# Altium schematic sheet Buck Converter.SchDoc(Designator)
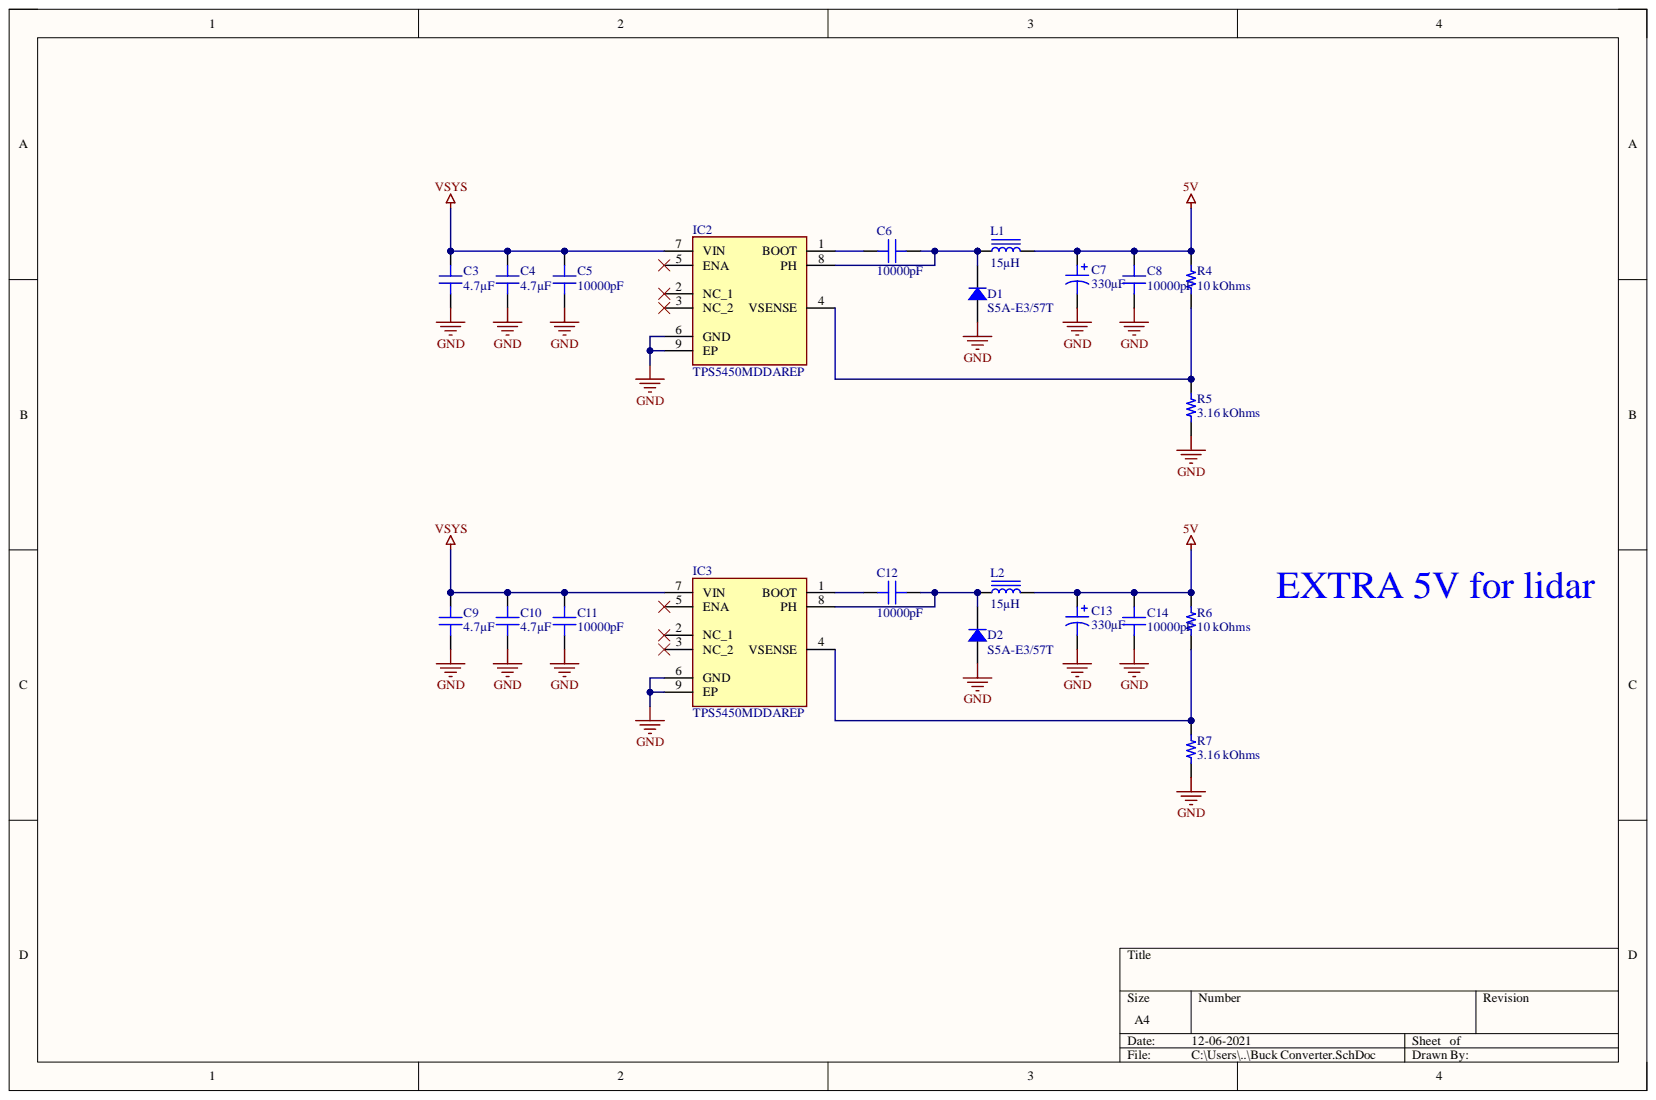
[diagram p0: Buck Converter.SchDoc]

## Parts
C3  4.7µF  [CAP 1206_3216 - 1.0MM]
C4  4.7µF  [CAP 1206_3216 - 1.0MM]
C5  10000pF  [CAP 0603_1608]
C6  10000pF  [CAP 0603_1608]
C7  330µF  [CAP ALSMD 6.6MM H7.7MM]
C8  10000pF  [CAP 0603_1608]
C9  4.7µF  [CAP 1206_3216 - 1.0MM]
C10  4.7µF  [CAP 1206_3216 - 1.0MM]
C11  10000pF  [CAP 0603_1608]
C12  10000pF  [CAP 0603_1608]
C13  330µF  [CAP ALSMD 6.6MM H7.7MM]
C14  10000pF  [CAP 0603_1608]
D1  S5A-E3/57T  [DO214AB SMC]
D2  S5A-E3/57T  [DO214AB SMC]
IC2  TPS5450MDDAREP  [SOIC127P600X170-9N]
IC3  TPS5450MDDAREP  [SOIC127P600X170-9N]
L1  15µH  [BOURNS SRR1280]
L2  15µH  [BOURNS SRR1280]
R4  10 kOhms  [RES 0603_1608]
R5  3.16 kOhms  [RES 0603_1608]
R6  10 kOhms  [RES 0603_1608]
R7  3.16 kOhms  [RES 0603_1608]

## Nets
5V: C7.1 C8.2 C13.1 C14.2 L1.2 L2.2 R4.2 R6.2
GND: C3.1 C4.1 C5.1 C7.2 C8.1 C9.1 C10.1 C11.1 C13.2 C14.1 D1.1 D2.1 IC2.6(GND) IC2.9(EP) IC3.6(GND) IC3.9(EP) R5.1 R7.1
VSYS: C3.2 C4.2 C5.2 C9.2 C10.2 C11.2 IC2.7(VIN) IC3.7(VIN)
NetC6_1: C6.1 D1.2 IC2.8(PH) L1.1
NetC6_2: C6.2 IC2.1(BOOT)
NetC12_1: C12.1 D2.2 IC3.8(PH) L2.1
NetC12_2: C12.2 IC3.1(BOOT)
NetIC2_4: IC2.4(VSENSE) R4.1 R5.2
NetIC3_4: IC3.4(VSENSE) R6.1 R7.2

Not connected: IC2 2(NC_1) 3(NC_2) 5(ENA); IC3 2(NC_1) 3(NC_2) 5(ENA)

## Library data of the parts
C3 C4 C9 C10 = C3216X7R1E475K085AB: CAP CER 4.7UF 25V X7R 1206; Manufacturer: TDK Corporation; Database Table Name: Capacitors - Ceramic - 1206; Tolerance: ±10%; Applications: General Purpose; Capacitance: 4.7µF; Component Kind: Standard; ComponentLink1Description: Datasheet; ComponentLink1URL: https://product.tdk.com/info/en/catalog/datasheets/mlcc_commercial_general_en.pdf?ref_disty=digikey; ComponentLink2Description: DigiKey Link; ComponentLink2URL: http://digikey.com/product-detail/en/tdk-corporation/C3216X7R1E475K085AB/445-14797-1-ND/3956463; Device Package: 1206 (3216 Metric); Features: Low ESL; LastUpdated: 2020-09-02 1:05:06 AM; Library Name: Celestial Altium Library - altium_library.DbLib; Lifecycle Status: Active; Minimum Order: 1; Mounting Type: Surface Mount, MLCC; Operating Temperature: -55°C ~ 125°C; Package / Case: 1206 (3216 Metric); Packaging: TapeAndReel; Part Number: C3216X7R1E475K085AB; Part Status: Active; PartId: 19105; Price: 0.08; Series: C; Size / Dimension: 0.126" L x 0.063" W (3.20mm x 1.60mm); Supplier 1: DigiKey; Supplier Part Number 1: 445-14797-1-ND; Temperature Coefficient: X7R; Thickness (Max): 0.039" (1.00mm); Value: 4.7µF; Voltage - Rated: 25V
C5 C6 C8 C11 C12 C14 = C0603C103K5RAC7081: CE SMD 0603 .01UF 10% 50V X7R 2M; Manufacturer: KEMET; Database Table Name: Capacitors - Ceramic - 0603; Applications: Bypass, Decoupling; Capacitance: 10000pF; Component Kind: Standard; ComponentLink1Description: Datasheet; ComponentLink1URL: https://api.kemet.com/component-edge/download/specsheet/C0603C103K5RAC7081.pdf; ComponentLink2Description: DigiKey Link; ComponentLink2URL: http://digikey.com/product-detail/en/kemet/C0603C103K5RAC7081/399-C0603C103K5RAC7081CT-ND/10483117; Device Package: 0603 (1608 Metric); LastUpdated: 2020-09-02 1:03:31 AM; Library Name: Celestial Altium Library - altium_library.DbLib; Lifecycle Status: Active; Minimum Order: 1; Mounting Type: Surface Mount, MLCC; Operating Temperature: -55°C ~ 125°C; Package / Case: 0603 (1608 Metric); Packaging: TapeAndReel; Part Number: C0603C103K5RAC7081; Part Status: Active; PartId: 5246; Price: 0.01; Series: SMD Comm X7R; Size / Dimension: 0.063" L x 0.031" W (1.60mm x 0.80mm); Supplier 1: DigiKey; Supplier Part Number 1: 399-C0603C103K5RAC7081CT-ND; Temperature Coefficient: X7R; Thickness (Max): 0.034" (0.87mm); Tolerance: ±10%; Value: 10000pF; Voltage - Rated: 50V
C7 C13 = 875105245015: CAP ALUM POLY 330UF 20% 10V SMD; Manufacturer: Würth Elektronik; Database Table Name: Capacitors - Aluminum - Polymer SMT; ESR (Equivalent Series Resistance): 20mOhm; Lifetime @ Temp.: 2000 Hrs @ 105°C; Ripple Current @ High Frequency: 2.69A @ 100kHz; Ripple Current @ Low Frequency: 134.5mA @ 120Hz; Surface Mount Land Size: 0.260" L x 0.260" W (6.60mm x 6.60mm); Type: Polymer; Applications: General Purpose; Capacitance: 330µF; Component Kind: Standard; ComponentLink1Description: Datasheet; ComponentLink1URL: https://katalog.we-online.de/pbs/datasheet/875105245015.pdf; ComponentLink2Description: DigiKey Link; ComponentLink2URL: http://digikey.com/product-detail/en/w-rth-elektronik/875105245015/732-6415-1-ND/5147966; Device Package: Radial, Can - SMD; Height - Seated (Max): 0.303" (7.70mm); LastUpdated: 2020-09-02 1:25:32 AM; Library Name: Celestial Altium Library - altium_library.DbLib; Lifecycle Status: Active; Minimum Order: 1; Mounting Type: Surface Mount; Operating Temperature: -55°C ~ 105°C; Package / Case: Radial, Can - SMD; Packaging: TapeAndReel; Part Number: 875105245015; Part Status: Active; PartId: 2228; Price: 0.40; Series: WCAP-PSLP; Size / Dimension: 0.248" Dia (6.30mm); Supplier 1: DigiKey; Supplier Part Number 1: 732-6415-1-ND; Tolerance: ±20%; Value: 330µF; Voltage - Rated: 10V
D1 D2 = S5A-E3/57T: DIODE GEN PURP 50V 5A DO214AB; Manufacturer: Vishay Semiconductor Diodes Division; Capacitance @ Vr, F: 40pF @ 4V, 1MHz; Current - Reverse Leakage @ Vr: 10µA @ 50V; Database Table Name: Diodes - Rectifiers - Single; Diode Type: Standard; Reverse Recovery Time (trr): 2.5µs; Speed: Standard Recovery >500ns, > 200mA (Io); Voltage - Forward (Vf) (Max) @ If: 1.15V @ 5A; Component Kind: Standard; ComponentLink1Description: Datasheet; ComponentLink1URL: http://www.vishay.com/docs/88931/s5a.pdf; ComponentLink2Description: DigiKey Link; ComponentLink2URL: http://digikey.com/product-detail/en/vishay-semiconductor-diodes-division/S5A-E3-57T/S5A-E3-57TGICT-ND/3646220; Current - Average Rectified (Io): 5A; LastUpdated: 2020-09-02 1:18:57 AM; Library Name: Celestial Altium Library - altium_library.DbLib; Lifecycle Status: Active; Minimum Order: 1; Mounting Type: Surface Mount; Operating Temperature - Junction: -55°C ~ 150°C; Package / Case: DO-214AB, SMC; Packaging: TapeAndReel; Part Number: S5A-E3/57T; Part Status: Active; PartId: 381; Price: 0.20; Series: -; Supplier 1: DigiKey; Supplier Device Package: DO-214AB (SMC); Supplier Part Number 1: S5A-E3/57TGICT-ND; Voltage - DC Reverse (Vr) (Max): 50V
IC2 IC3 = TPS5450MDDAREP: Integrated Circuit; Manufacturer_Name: Texas Instruments; Component Kind: Standard; Datasheet Link: http://www.ti.com/lit/gpn/tps5450-ep; Height: 1.7mm; Library Name: SamacSys.SchLib; Manufacturer_Part_Number: TPS5450MDDAREP
L1 L2 = SRR1280-150M: FIXED IND 15UH 5.2A 28.5MOHM SMD; Manufacturer: Bourns Inc.; Current - Saturation: 5A; Database Table Name: Inductors - Power; DC Resistance (DCR): 28.5mOhm Max; Frequency - Self Resonant: 13MHz; Inductance Frequency - Test: 100kHz; Material - Core: Ferrite; Q @ Freq: 26 @ 2.52MHz; Shielding: Shielded; Tolerance: ±20%; Type: Wirewound; Component Kind: Standard; ComponentLink1Description: Datasheet; ComponentLink1URL: https://www.bourns.com/docs/Product-Datasheets/SRR1280.pdf; ComponentLink2Description: DigiKey Link; ComponentLink2URL: http://digikey.com/product-detail/en/bourns-inc/SRR1280-150M/SRR1280-150MCT-ND/2127431; Current Rating (Amps): 5.2A; Device Package: Nonstandard; Height - Seated (Max): 0.315" (8.00mm); Inductance: 15µH; LastUpdated: 2020-09-02 1:22:10 AM; Library Name: Celestial Altium Library - altium_library.DbLib; Lifecycle Status: Active; Minimum Order: 1; Mounting Type: Surface Mount; Operating Temperature: -40°C ~ 125°C; Package / Case: Nonstandard; Packaging: TapeAndReel; Part Number: SRR1280-150M; Part Status: Active; PartId: 20; Price: 0.65; Series: SRR1280; Size / Dimension: 0.492" L x 0.492" W (12.50mm x 12.50mm); Supplier 1: DigiKey; Supplier Part Number 1: SRR1280-150MCT-ND; Value: 15µH
R4 R6 = AF0603FR-0710KL: RES SMD 10K OHM 1% 1/10W 0603; Manufacturer: Yageo; Database Table Name: Resistors - Surface Mount - 0603; Power (Watts): 0.1W, 1/10W; Temperature Coefficient: ±100ppm/°C; Component Kind: Standard; ComponentLink1Description: Datasheet; ComponentLink1URL: http://www.yageo.com/documents/recent/PYu-AF_51_RoHS_L_6.pdf; ComponentLink2Description: DigiKey Link; ComponentLink2URL: http://digikey.com/product-detail/en/yageo/AF0603FR-0710KL/311-10KLMCT-ND/2828170; Composition: Thick Film; Device Package: 0603 (1608 Metric); Features: Anti-Sulfur, Moisture Resistant; Height - Seated (Max): 0.022" (0.55mm); LastUpdated: 2020-09-02 1:10:01 AM; Library Name: Celestial Altium Library - altium_library.DbLib; Lifecycle Status: Active; Minimum Order: 1; Number of Terminations: 2; Operating Temperature: -55°C ~ 155°C; Package / Case: 0603 (1608 Metric); Packaging: TapeAndReel; Part Number: AF0603FR-0710KL; Part Status: Active; PartId: 16021; Price: 0.01; Resistance: 10 kOhms; Series: AF; Size / Dimension: 0.063" L x 0.031" W (1.60mm x 0.80mm); Supplier 1: DigiKey; Supplier Device Package: 0603; Supplier Part Number 1: 311-10KLMCT-ND; Tolerance: ±1%; Value: 10 kOhms
R5 R7 = CR0603-FX-3161ELF: RES SMD 3.16K OHM 1% 1/10W 0603; Manufacturer: Bourns Inc.; Database Table Name: Resistors - Surface Mount - 0603; Power (Watts): 0.1W, 1/10W; Temperature Coefficient: ±100ppm/°C; Component Kind: Standard; ComponentLink1Description: Datasheet; ComponentLink1URL: https://www.bourns.com/docs/Product-Datasheets/CHPREZTR.pdf; ComponentLink2Description: DigiKey Link; ComponentLink2URL: http://digikey.com/product-detail/en/bourns-inc/CR0603-FX-3161ELF/CR0603-FX-3161ELFCT-ND/4247773; Composition: Thick Film; Device Package: 0603 (1608 Metric); Features: Moisture Resistant; Height - Seated (Max): 0.022" (0.55mm); LastUpdated: 2020-09-02 1:10:18 AM; Library Name: Celestial Altium Library - altium_library.DbLib; Lifecycle Status: Active; Minimum Order: 1; Number of Terminations: 2; Operating Temperature: -55°C ~ 155°C; Package / Case: 0603 (1608 Metric); Packaging: CutTape; Part Number: CR0603-FX-3161ELF; Part Status: Active; PartId: 8282; Price: 0.10; Resistance: 3.16 kOhms; Series: CR0603; Size / Dimension: 0.063" L x 0.031" W (1.60mm x 0.80mm); Supplier 1: DigiKey; Supplier Device Package: 0603; Supplier Part Number 1: CR0603-FX-3161ELFCT-ND; Tolerance: ±1%; Value: 3.16 kOhms
